# Altium schematic sheet corrected.SchDoc
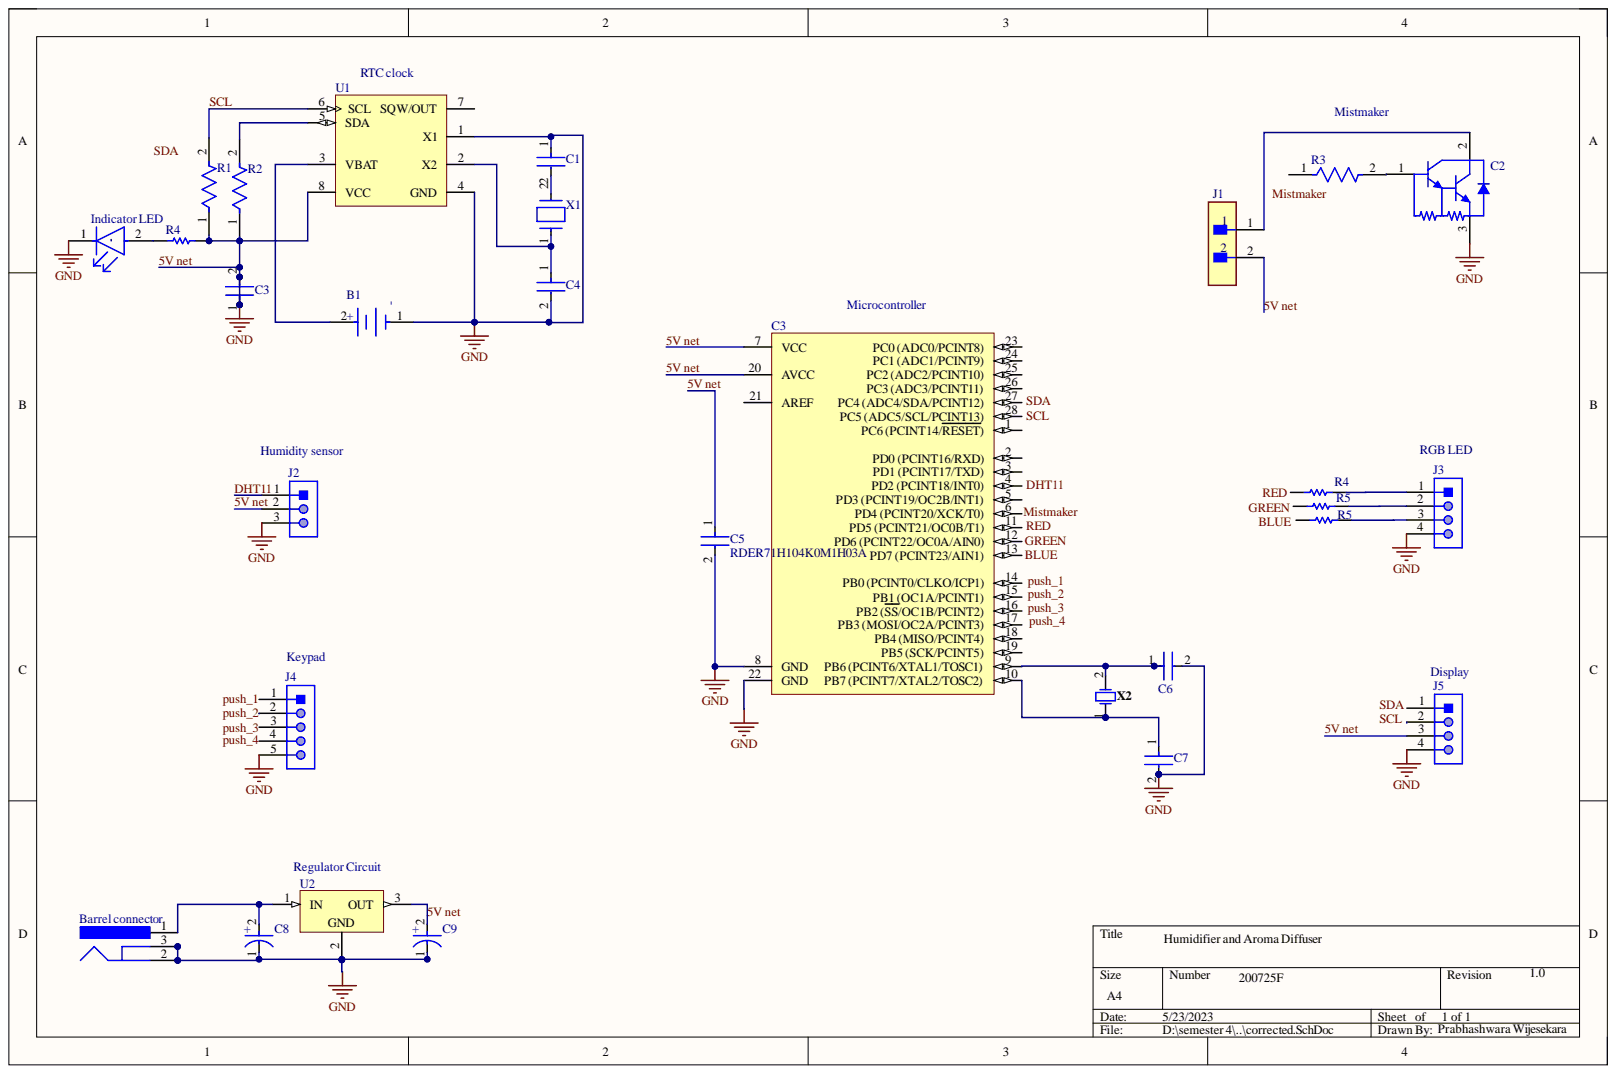
[diagram p0: corrected.SchDoc]

## Parts
B1  BU2032SM-HD-G  [FP-BU2032SM-HD-G-MFG]  (value not drawn)
Barrel_connector  PJ-002A  [CUI-PJ-002A_V]  (value not drawn)
C1  RCE5C1H120J0DBH03A  [FP-RCE0DB-MFG]  (value not drawn)
C2  TIP120G  [ONSC-TO-220-3-221A-09]  (value not drawn)
C3  RCER71H104K0K1H03B  [FP-RCE0K1-MFG]  (value not drawn)
C3  ATmega328-PU  [28P3]  (value not drawn)
C4  RCE5C1H120J0DBH03A  [FP-RCE0DB-MFG]  (value not drawn)
C5  RDER71H104K0M1H03A  [FP-RDE0M1-MFG]
C6  RCE5C1H220J0K1H03B  [FP-RCE0K1-MFG]  (value not drawn)
C7  RCE5C1H220J0K1H03B  [FP-RCE0K1-MFG]  (value not drawn)
C8  EEUFR1A472L  [FP-RAD-TH-D_12_5_0_5-L_30-MFG]  (value not drawn)
C9  EEUFR1A472L  [FP-RAD-TH-D_12_5_0_5-L_30-MFG]  (value not drawn)
Indicator_LED_  Reverse Voltage: 5V  [FP-HLMP-1503-MFG]  (hidden comment: HLMP-1503)  (part )
J1  M20-9990246  [FP-M20-9990246-MFG]  (value not drawn)
J2  61300311121  [61300311121]  (value not drawn)
J3  61300411121  [61300411121]  (value not drawn)
J4  61300511121  [61300511121]  (value not drawn)
J5  61300411121  [61300411121]  (value not drawn)
R1  CF18JT2K20  [FP-CF18-MFG]  (value not drawn)
R2  CF18JT2K20  [FP-CF18-MFG]  (value not drawn)
R3  CF18JT2K20  [FP-CF18-MFG]  (value not drawn)
R4  MFR-25FBF52-1K  [RESA60-630X240]  (value not drawn)
R4  MFR-25FBF52-1K  [RESA60-630X240]  (value not drawn)
R5  MFR-25FBF52-1K  [RESA60-630X240]  (value not drawn)
R5  MFR-25FBF52-1K  [RESA60-630X240]  (value not drawn)
U1  DS1307+  [P8+2]  (value not drawn)
U2  LM7805CT  [FAIR-TO-220-3]  (value not drawn)
X1  AB26TRB-32.768KHZ-T  [FP-AB26TRB-MFG]  (value not drawn)
X2  FOXSLF/160-20  [FOX-FOXSLF160-20]  (value not drawn)

## Nets
5V net: B1.1 Barrel_connector.2 Barrel_connector.3 C1.1 C2.3 C3.1 C3.2 C3.7(VCC) C3.8(GND) C3.20(AVCC) C3.22(GND) C4.2 C5.1 C5.2 C6.2 C7.2 C8.1 C9.1 C9.2 Indicator_LED_.1 J1.2 J2.2 J2.3 J3.4 J4.5 J5.3 J5.4 R1.1 R2.1 R4.2 U1.1(X1) U1.4(GND) U1.8(VCC) U2.2 U2.3
DHT11: J2.1
SCL: R1.2 U1.6(SCL)
NetB1_2: B1.2 U1.3(VBAT)
NetBarrel connector_1: Barrel_connector.1 C8.2 U2.1
NetC1_2: C1.2 X1.2
NetC2_1: C2.1 R3.2
NetC2_2: C2.2 J1.1
NetC3_9: C3.9 C6.1 X2.2
NetC3_10: C3.10 C7.1 X2.1
NetC4_1: C4.1 U1.2(X2) X1.1
NetIndicator LED _2: Indicator_LED_.2 R4.1
NetJ3_1: J3.1 R4.2
NetJ3_2: J3.2 R5.2
NetJ3_3: J3.3 R5.2
NetR2_2: R2.2 U1.5(SDA)
BLUE:
GREEN:
Mistmaker:
push_1:
push_2:
push_3:
push_4:
RED:
SDA:

Not connected: C3 3(PD1_(PCINT17/TXD)) 4(PD2_(PCINT18/INT0)) 5(PD3_(PCINT19/OC2B/INT1)) 6(PD4_(PCINT20/XCK/T0)) 11(PD5_(PCINT21/OC0B/T1)) 12(PD6_(PCINT22/OC0A/AIN0)) 13(PD7_(PCINT23/AIN1)) 14(PB0_(PCINT0/CLKO/ICP1)) 15(PB1_(OC1A/PCINT1)) 16(PB2_(SS/OC1B/PCINT2)) 17(PB3_(MOSI/OC2A/PCINT3)) 18(PB4_(MISO/PCINT4)) 19(PB5_(SCK/PCINT5)) 21(AREF) 23(PC0_(ADC0/PCINT8)) 24(PC1_(ADC1/PCINT9)) 25 26(PC3_(ADC3/PCINT11)) 27 28(PC5_(ADC5/SCL/PCINT13)); J4 1 2 3 4; J5 1 2; R3 1; R5 1; U1 7(SQW/OUT)

## Library data of the parts
B1 = CMP-136216-000005-1: BATTERY HOLDER COIN 20MM SMD; Number of Cells: 1; Case/Package: SMD/SMT; Component Kind: Standard; Diameter: 20mm; Lead Free: Lead Free; Library Name: Altium Content Vault; Max Operating Temperature: 280°C; Min Operating Temperature: -40°C; Mount: Surface Mount; Package Quantity: 1; Packaging: Cut Tape; Termination: SMD/SMT
Barrel_connector = CMP-2000-06895-2: Through Hole Right Angle DC Power Jack, 2.5 A, 2 mm Center Pin, 3 Position, -25 to 85 degC, RoHS, Bulk; Manufacturer: CUIInc.; Brand: CUI; Current Rating: 2.5A; Inside Contact Diameter: 2mm; Insulation Resistance: 50MOhms; Outside Contact Diameter: 6.5mm; Voltage Rating: 24V; Component Kind: Standard; Connector Type: DCPowerJack; Contact Gender: Male; Contact Material: Copper; Contact Plating: Nickel; Datasheet URL: http://www.cui.com/product/resource/pj-002a.pdf; Datasheet Version: Rev. 1.03, 04/2016; Factory Pack Quantity: 500; Flammability Rating: UL94V-0; Housing Material: PolybutyleneTerephthalate(PBT); Library Name: Altium Content Vault; Manufacturer URL: http://www.cui.com/; Mounting Angle: Right; Mounting Style: ThroughHole; Mounting Technology: ThroughHole; Operating Temperature Range: -25Cto+85C; Package Description: 3-Pin Through Hole Device, Body 14.4 x 9 mm, Height 11 mm; Package Reference: PJ-002A; Product: Jacks; Product Category: DCPowerConnectors; RoHS: True; Termination Style: ThroughHole
C1 C4 = CMP-06035-009458-1: Cap Ceramic 12pF 50V C0G ±5% Radial 2.5mm +125°C Ammo Pack; Lead Diameter: 0.5mm; Lead/Base Style: Straight; Capacitance: 12pF; Case/Package: Radial; Component Kind: Standard; Dielectric: C0G; Height: 3.5mm; Height - Seated (Max): 0.138inch; Lead Pitch: 0.098inch; Length: 0.138inch; Library Name: Altium Content Vault; Max Operating Temperature: 125°C; Min Operating Temperature: -55°C; Mount: Through Hole; Package Quantity: 2000; Packaging: Tape and Reel; Ratings: AEC-Q200; Resistor Type: Ceramic; Termination: Radial; Tolerance: 5%; Voltage Rating: 50V; Voltage Rating (DC): 50V; Width: 0.098inch
C2 = CMP-1047-00134-1: Plastic Medium-Power, Complementary Silicon Transistor, 3-Pin TO-220, Pb-Free, Tube; Manufacturer: On Semiconductor; IC Continuous (A): 5; Polarity: NPN; Component Kind: Standard; ComponentLink1Description: Manufacturer URL; ComponentLink1URL: http://www.onsemi.com/; ComponentLink2Description: Datasheet; ComponentLink2URL: http://www.onsemi.cn/pub_link/Collateral/TIP120-D.PDF; ComponentLink3Description: Package Specification; ComponentLink3URL: http://www.onsemi.com/pub_link/Collateral/221A-09.PDF; DatasheetVersion: Rev. 8; Library Name: Altium Content Vault; Mounting Technology: Through Hole; PackageDescription: 3-Pin Flange Mount, Vertical Straight Lead, Body 9.97 x 4.445 mm, Pitch 2.54 mm; PackageReference: TO-220-3-221A-09; PackageVersion: Rev. AG, 09/2011; Packing: Tube; PartNumber: TIP120G; RoHS: TRUE
C3 = CMP-06035-058483-1: Cap Ceramic 100nF 50V X7R ±10% Radial 5mm +125°C Automotive Bulk; Lead Diameter: 0.5mm; Lead/Base Style: Formed Leads; Capacitance: 100nF; Case/Package: Radial; Component Kind: Standard; Depth: 2.5mm; Dielectric: X7R; Height: 3.5mm; Height - Seated (Max): 0.138inch; Lead Free: Lead Free; Lead Pitch: 0.197inch; Length: 3.6mm; Library Name: Altium Content Vault; Max Operating Temperature: 125°C; Min Operating Temperature: -55°C; Mount: Through Hole; Package Quantity: 500; Packaging: Bulk; Ratings: AEC-Q200; Resistor Type: Ceramic; Termination: Radial; Tolerance: 10%; Voltage: 50V; Voltage Rating: 50V; Voltage Rating (DC): 50V; Width: 0.098inch
C3 = CMP-0095-00015-2: 8-bit AVR Microcontroller, 32KB Flash, 1KB EEPROM, 2KB SRAM, 28-pin PDIP, Industrial Grade (-40°C to 85°C); Component Kind: Standard; ComponentLink1Description: Manufacturer URL; ComponentLink1URL: http://www.atmel.com/; ComponentLink2Description: ATmega48A/48PA/88A/88PA/168A/168PA/328/328P Datasheet; ComponentLink2URL: http://www.atmel.com/dyn/resources/prod_documents/8271.pdf; ComponentLink3Description: ATmega48A/48PA/88A/88PA/168A/168PA/328/328P Summary; ComponentLink3URL: http://www.atmel.com/dyn/resources/prod_documents/8271S.pdf; DatasheetVersion: revD, May-2011; Library Name: Altium Content Vault; PackageDescription: 28-lead (0.300"/7.62mm Wide) Plastic Dual Inline Package (PDIP); PackageReference: 28P3; PackageVersion: revB, Sep-2001; RevisionNote: DatasheetVersion updated. URLs added.
C5 = CMP-06035-051680-1: Ceramic Capacitor 100nF ±10% 50V X7R Radial; Lead Diameter: 0.5mm; Lead/Base Style: Kinked; Capacitance: 100nF; Case/Package: Radial; Component Kind: Standard; Dielectric: X7R; Height: 3.5mm; Height - Seated (Max): 0.236inch; Lead Free: Lead Free; Lead Pitch: 0.197inch; Length: 0.157inch; Library Name: Altium Content Vault; Max Operating Temperature: 125°C; Min Operating Temperature: -55°C; Mount: Through Hole; Package Quantity: 2000; Packaging: Tape and Reel; Resistor Type: Ceramic; Termination: Radial; Tolerance: 10%; Voltage Rating: 50V; Voltage Rating (DC): 50V; Width: 3.5mm
C6 C7 = CMP-06035-047580-1: Cap Ceramic 22pF 50V C0G ±5% Radial 5mm +125°C Automotive Bulk; Lead Diameter: 0.5mm; Lead/Base Style: Formed Leads; Capacitance: 22pF; Case/Package: Radial; Component Kind: Standard; Dielectric: C0G; Height: 3.5mm; Height - Seated (Max): 0.138inch; Lead Pitch: 0.197inch; Length: 0.142inch; Library Name: Altium Content Vault; Max Operating Temperature: 125°C; Min Operating Temperature: -55°C; Mount: Through Hole; Package Quantity: 500; Packaging: Bulk; Ratings: AEC-Q200; Resistor Type: Ceramic; Termination: Radial; Tolerance: 5%; Voltage Rating: 50V; Voltage Rating (DC): 50V; Width: 0.098inch
C8 C9 = CMP-05427-001008-1: Aluminum Electrolytic Capacitors (Radial Lead Type) 4700uF ±20% 10V; Capacitance: 4.7mF; Dielectric Material: Aluminium; ESR (Equivalent Series Resistance): 13mR; Lead Diameter: 0.8mm; Lead/Base Style: Straight; Life (Hours): 10000Hour; Ripple Current: 3.63A; Case/Package: Radial; Component Kind: Standard; Construction: RADIAL; Diameter: 12.5mm; Height: 30mm; Height - Seated (Max): 1.26inch; Impedance: 13mR; Lead Pitch: 5mm; Length: 30mm; Library Name: Altium Content Vault; Max Operating Temperature: 105°C; Min Operating Temperature: -40°C; Mount: Through Hole; Number of Pins: 2; Package Quantity: 100; Packaging: Bulk; Radiation Hardening: No; REACH SVHC: Yes; Ripple Current (AC): 3.63A; RoHS Compliant: Yes; Series: FR; Termination: Radial; Tolerance: 20%; Voltage: 10V; Voltage Rating: 10V; Voltage Rating (DC): 10V
Indicator_LED_ = CMP-18399-000010-1: LED GREEN DIFFUSED T-1 T/H; Manufacturer: Broadcom; Forward Current: 10mA; Forward Voltage: 2.1V; Led Orientation: Straight; Luminous Intensity: 1mcd; Max Junction Temp: +110°C; Peak Emmision Wavelength: 565nm; Standoff Height: 0.88mm; Viewing Angle: 60°; Wavelength: 569nm; Automotive: No; Category: Diode; Colour: Green; Component Kind: Standard; ComponentLink2Description: Datasheet; ComponentLink2URL: https://docs.broadcom.com/docs/AV02-1555EN; Device Class L1: Optoelectronics; Device Class L2: LEDs; Device Class L3: unset; Digikey Description: LED GREEN DIFFUSED T-1 T/H; Height: 6.35mm; Lead Free: Yes; Library Name: Altium Content Vault; Manufacturer Part Number: HLMP-1503; Mouser Description: LED; Green; 1mcd; 0.115In.Dia.; T-1; 565nm; 25mA; 2.1V; 5V; GaP; -20degC; 100deg; Octopart: https://octopart.com/hlmp-1503-broadcom-71130371; Package: HLMP-1503; RoHS: TRUE; Temperature: -20°C to +100°C; Temperature Range High: +100°C; Temperature Range Low: -20°C
J1 = CMP-15831-000009-1: CONN HEADER VERT 2POS 2.54MM; Manufacturer: Harwin; Conn Gender: Male; Conn Orientation: Vertical; Current Rating: 3A; Number Of Contacts: 2; Automotive: No; Category: Conn; Component Kind: Standard; ComponentLink2Description: Datasheet; ComponentLink2URL: https://cdn.harwin.com/pdfs/M20-999.pdf; Contact Material: Tin,Nickel; Device Class L1: Connectors; Device Class L2: Headers and Wire Housings; Device Class L3: unset; Digikey Description: CONN HEADER VERT 2POS 2.54MM; Height: 8.99mm; Lead Free: Yes; Library Name: Altium Content Vault; Manufacturer Part Number: M20-9990246; Mouser Description: 2.54mm (0.1") Pitch SIL Vertical PC Tail Pin Header, 6.1mm mating pin height, tin, 2 contacts; Number Of Rows: 1; Octopart: https://octopart.com/m20-9990246-harwin-2590635; Package: M20-9990246; Pitch: 2.54mm; RoHS: TRUE; Temperature: -40°C to +105°C; Temperature Range High: +105°C; Temperature Range Low: -40°C
J2 = CMP-1502-01062-1: THT Vertical Pin Header WR-PHD, Pitch 2.54 mm, Single Row, 3 pins; Manufacturer: Wurth Elektronik; Contact Resistance: 20mOhm; Gender: Male; Pin Number: 3; Pitch: 2.54mm; Rated Current: 3A; Withstanding Voltage: 500VAC; Working Voltage: 250VAC; Component Kind: Standard; Datasheet URL: https://katalog.we-online.de/em/datasheet/6130xx11121.pdf; Library Name: Altium Content Vault; Manufacturer URL: http://www.we-online.de; Mounting Technology: THT; Operating Temperature: -40to105degC; Orientation: Vertical; Package Reference: 61300311121; Packaging: Bag; Part Number: 61300311121; Row Number: Single; Publisher: WurthElektronik
J3 J5 = CMP-1502-01064-1: THT Vertical Pin Header WR-PHD, Pitch 2.54 mm, Single Row, 4 pins; Manufacturer: Wurth Elektronik; Contact Resistance: 20mOhm; Gender: Male; Pin Number: 4; Pitch: 2.54mm; Rated Current: 3A; Withstanding Voltage: 500VAC; Working Voltage: 250VAC; Component Kind: Standard; Datasheet URL: https://katalog.we-online.de/em/datasheet/6130xx11121.pdf; Library Name: Altium Content Vault; Manufacturer URL: http://www.we-online.de; Mounting Technology: THT; Operating Temperature: -40to105degC; Orientation: Vertical; Package Reference: 61300411121; Packaging: Bag; Part Number: 61300411121; Row Number: Single; Publisher: WurthElektronik
J4 = CMP-1502-01068-1: THT Vertical Pin Header WR-PHD, Pitch 2.54 mm, Single Row, 5 pins; Manufacturer: Wurth Elektronik; Contact Resistance: 20mOhm; Gender: Male; Pin Number: 5; Pitch: 2.54mm; Rated Current: 3A; Withstanding Voltage: 500VAC; Working Voltage: 250VAC; Component Kind: Standard; Datasheet URL: https://katalog.we-online.de/em/datasheet/6130xx11121.pdf; Library Name: Altium Content Vault; Manufacturer URL: http://www.we-online.de; Mounting Technology: THT; Operating Temperature: -40to105degC; Orientation: Vertical; Package Reference: 61300511121; Packaging: Bag; Part Number: 61300511121; Row Number: Single; Publisher: WurthElektronik
R1-R3 = CMP-26528-000001-1: RES 2.2K OHM 1/8W 5% CF AXIAL; Manufacturer: Stackpole Electronics Inc; Power Rating: 0.125W; Resistance: 2.2kΩ; Temperature Coefficient: 0/-400ppm/°C; Value: 2.2kΩ; Voltage Rating: 250V; Automotive: No; Category: Res; Component Kind: Standard; ComponentLink2Description: Datasheet; ComponentLink2URL: https://www.seielect.com/catalog/sei-cf_cfm.pdf; Composition: Carbon Film; Device Class L1: Passive Components; Device Class L2: Resistors; Device Class L3: Through-Hole Resistors; Digikey Description: RES 2.2K OHM 1/8W 5% CF AXIAL; Height: 2mm; Ipc Land Pattern Name: RESAD656W65L360D200; Lead Free: Yes; Library Name: Altium Content Vault; Manufacturer Part Number: CF18JT2K20; Octopart: https://octopart.com/cf18jt2k20-stackpole+electronics-19205714; Package: CF18; RoHS: TRUE; Series: CF; Temperature: -55°C to +155°C; Temperature Range High: +155°C; Temperature Range Low: -55°C; Tolerance: 5%
R4 R4 R5 R5 = CMP-1659-00075-1: Axial Resistor, 1 KOhm, +/- 1%, 250 mW, -55 to 155 degC, 2-Pin THD, RoHS, Bulk; Power Rating: 250 mW; Resistance: 10 kohm; Voltage Rating: 250 V; Case\Package: Axial; Component Kind: Standard; ComponentLink1Description: Manufacturer URL; ComponentLink1URL: http://www.yageo.com/; ComponentLink2Description: Datasheet; ComponentLink2URL: http://www.yageo.com/documents/recent/Yageo%20LR_MFR_2013.pdf; DatasheetVersion: Apr-13; Length: 0.248 inch; Library Name: Altium Content Vault; Max Operating Temperature: 155 degC; Min Operating Temperature: -55 degC; Package Quantity: 200; PackageDescription: 2-Pin Axial Lead, Dia 2.4 mm, Body Length 6.3 mm; PackageReference: MFR-25; Packaging: Bulk; RoHSCompliant: true; Tolerance: 1%
U1 = CMP-0254-00152-1: 64 x 8, Serial, I2C Real-Time Clock, 5.0V, 8-Pin PDIP, 0 to 70 degC, Pb-Free; Code_JEDEC: MS-001-AB; Component Kind: Standard; ComponentLink1Description: Manufacturer URL; ComponentLink1URL: http://www.maxim-ic.com; ComponentLink2Description: Datasheet; ComponentLink2URL: http://datasheets.maxim-ic.com/en/ds/DS1307.pdf; ComponentLink3Description: Package Specification; ComponentLink3URL: http://pdfserv.maxim-ic.com/package_dwgs/21-0043.PDF; DatasheetVersion: 10-Feb-2008; Library Name: Altium Content Vault; PackageDescription: 8-Pin Plastic Dual-In-Line, Narrow (0.3in), Pitch 2.54 mm, PDIP(N), Pb-Free; PackageReference: P8+2; PackageVersion: revE, 2011
U2 = CMP-2000-04938-1: Positive Voltage Regulator, 5 V, 1 A, -40 to 125 degC, 3-Pin TO-220, RoHS, Tube; Manufacturer: FairchildSemiconductor; Accuracy: ±4%; Line Regulation: 100mV; Maximum Input Voltage: 35V; Maximum Output Current: 2.2(Typ)A; Maximum Quiescent Current: 8mA; Number of Outputs: 1; Output Type: Fixed; Output Voltage: 5V; Rad Hard: No; Typical Dropout Voltage @ Current: 2@1AV; Category: LinearRegulator; Component Kind: Standard; Datasheet URL: https://datasheet.ciiva.com/26904/lm7805ct-26904988.pdf; Datasheet Version: Aug-12; Library Name: Altium Content Vault; Load Regulation: 100mV; Manufacturer URL: https://www.fairchildsemi.com/; Mounting: ThroughHole; Operating Temperature: -40to125degC; Package: 3TO-220AB; Package Description: 3-Pin Through Hole Device, Body 10 x 4.5 mm, Pitch 2.54 mm; Package Reference: TO-220; Package URL: https://www.fairchildsemi.com/package-drawings/TO/TO220B03.pdf; Packaging: Rail/Tube; Polarity: Positive; Type: Linear
X1 = CMP-27762-006068-1: CRYSTAL 32.7680KHZ 12.5PF TH; ESR (Equivalent Series Resistance): 50kR; Frequency Stability: -0.034ppm; Frequency Tolerance: 20ppm; Case/Package: Radial; Component Kind: Standard; Depth: 1.9mm; Frequency: 32.768kHz; Height: 0.075inch; Lead Free: Lead Free; Lead Pitch: 2.54mm; Length: 6mm; Library Name: Altium Content Vault; Load Capacitance: 12.5pF; Max Operating Temperature: 85°C; Min Operating Temperature: -40°C; Mount: Surface Mount; Number of Pins: 2; Operating Frequency: 32.768 kHz; Package Quantity: 500; Packaging: Tape and Reel; REACH SVHC: No SVHC; Series: AB26TRB; Series Resistance: 50kR; Termination: Radial; Tolerance: 20ppm
X2 = CMP-1749-00003-1: Resistance Weld Thru-Hole Crystal, 16 MHz, -20 to 70 degC, 2-Pin THD, RoHS, Bulk; Case\Package: Axial; Power Rating: 250 mW; Resistance: 221 kohm; Tolerance: 1%; Voltage Rating: 250 V; Component Kind: Standard; ComponentLink1Description: Manufacturer URL; ComponentLink1URL: http://www.foxonline.com/; ComponentLink2Description: Datasheet; ComponentLink2URL: http://www.foxonline.com/pdfs/hc49slf.pdf; DatasheetVersion: Mar-15; Length: 0.248 inch; Library Name: Altium Content Vault; Max Operating Temperature: 155 degC; Min Operating Temperature: -55 degC; Mount: Through Hole; Package Quantity: 200; PackageDescription: 2-Pin Through Hole Device, Body 11.35 x 4.65 mm; PackageReference: FOXSLF160-20; Packaging: Bulk; RoHSCompliant: true
